# Altium schematic sheet Output Buffer.SchDoc
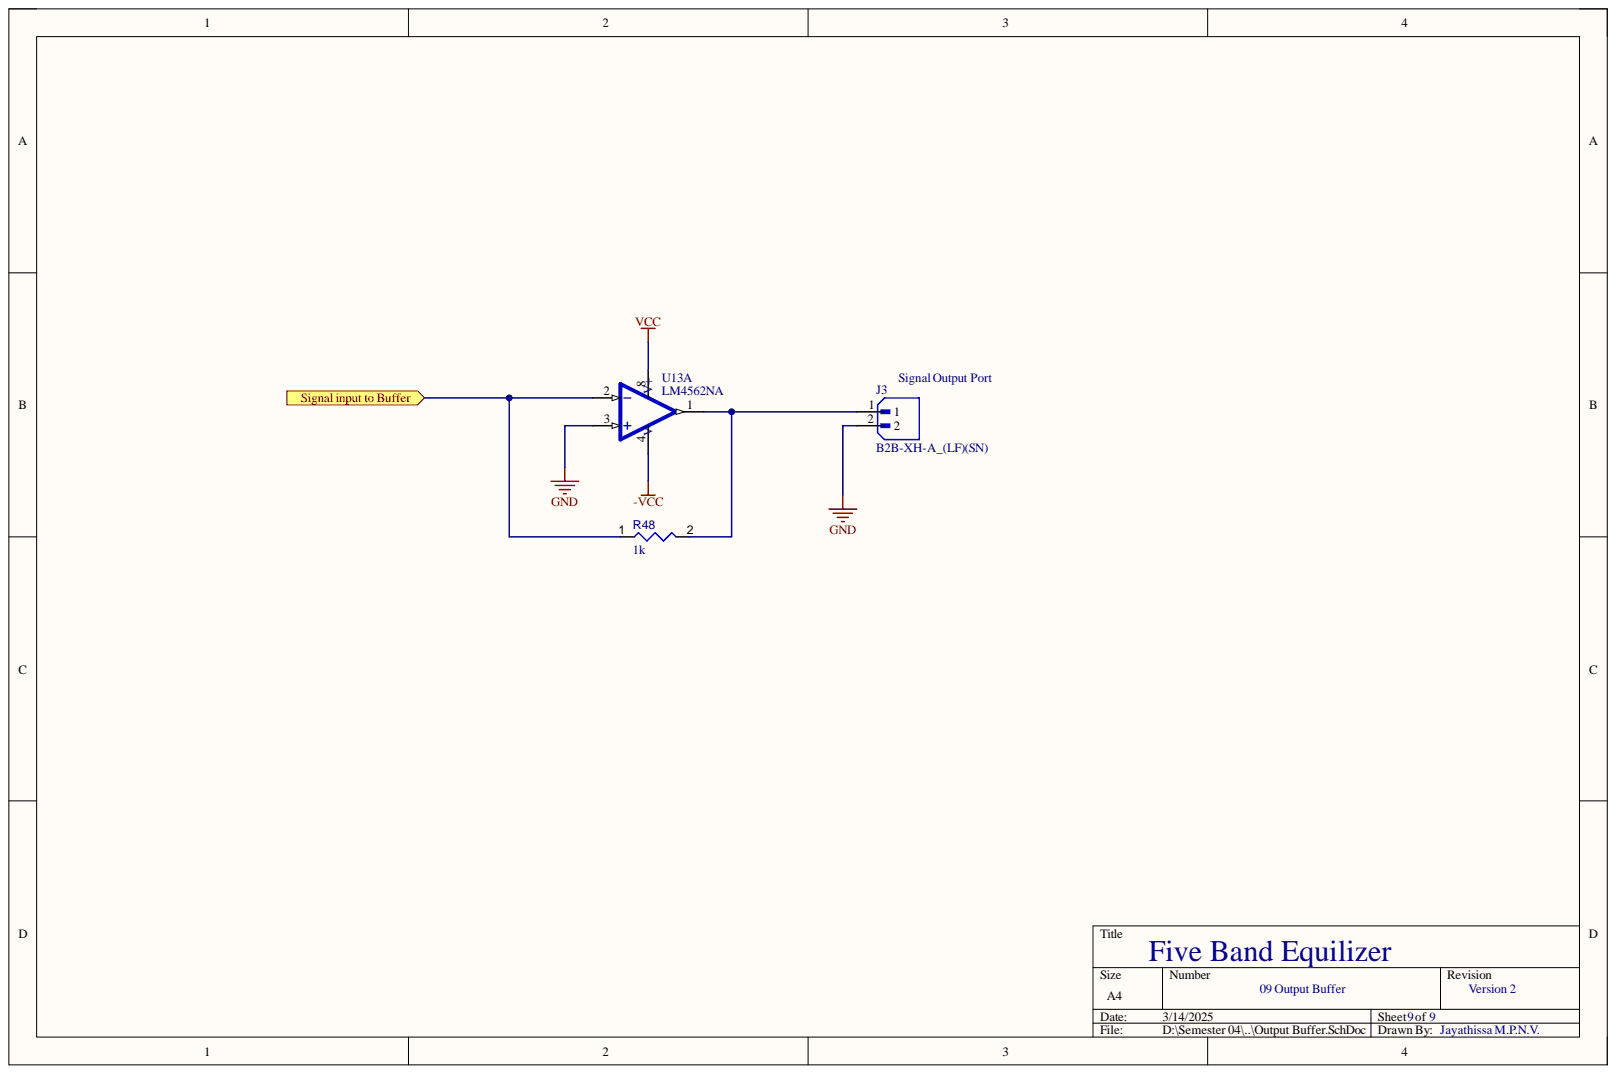
[diagram p0: Output Buffer.SchDoc]

## Parts
J3  B2B-XH-A_(LF)(SN)  [JST_B2B-XH-A_(LF)(SN)]
R48  value 1k  [RESC1608X55X25ML10T15]  (hidden comment: Resistor 1k +/-1% 0603 100 mW)
U13  LM4562NA  [DIP794W45P254L959H508Q8]  (part A)

## Ports
Signal input to Buffer

## Nets
-VCC: U13.4
GND: J3.2 U13.3(V-)
VCC: U13.8
Signal input to Buffer: R48.1 U13.2 ; port Signal input to Buffer
NetJ3_1: J3.1 R48.2 U13.1

## Library data of the parts
J3 = CMP-002-00072-1: Connector Header Through Hole 2 position 0.098 (2.50mm); MF: JST Sales; MP: B2B-XH-A (LF)(SN); Case/Package: None; Check_prices: https://www.snapeda.com/parts/B2B-XH-A%20(LF)(SN)/JST+Sales+America+Inc./view-part/?ref=eda; Component Kind: Standard; Component Type: Standard; Design Item ID: B2B-XH-A_(LF)(SN); Pins: 2; SnapEDA_Link: https://www.snapeda.com/parts/B2B-XH-A%20(LF)(SN)/JST+Sales+America+Inc./view-part/?ref=snap; Source: B2B-XH-A_(LF)(SN).SchLib; Library Name: Nirwan Jayathissa
R48 = CMP-009-00014-5: Chip Resistor, 1 KOhm, +/-1%, 0.1 W, -55 to 155 degC, 0603 (1608 Metric); Power: 100mW; Tolerance: 1%; Voltage Rating: 50V; Case/Package: 0603; Component Kind: Standard; Max Operating Temperature: 155°C; Min Operating Temperature: -55°C; Mounting Technology: SM; Pins: 2; RoHS Compliant: True; Library Name: Nirwan Jayathissa
U13 = CMP-014-00002-1: LM4562 2 - Dual Channels per IC Audio Amplifier Evaluation Board; MF: Texas Instruments; Availability: In Stock; Case/Package: DIP-8 Texas Instruments; Check_prices: https://www.snapeda.com/parts/LM4562NA/Texas+Instruments/view-part/?ref=eda; Component Kind: Standard; Component Type: Standard; Design Item ID: LM4562NA; MP: LM4562NA; Pins: 8; Price: None; Purchase-URL: https://pricing.snapeda.com/search/part/LM4562NA/?ref=eda; SnapEDA_Link: https://www.snapeda.com/parts/LM4562NA/Texas+Instruments/view-part/?ref=snap; Source: LM4562NA.SchLib; Library Name: Nirwan Jayathissa
